# Altium schematic sheet Display.SchDoc
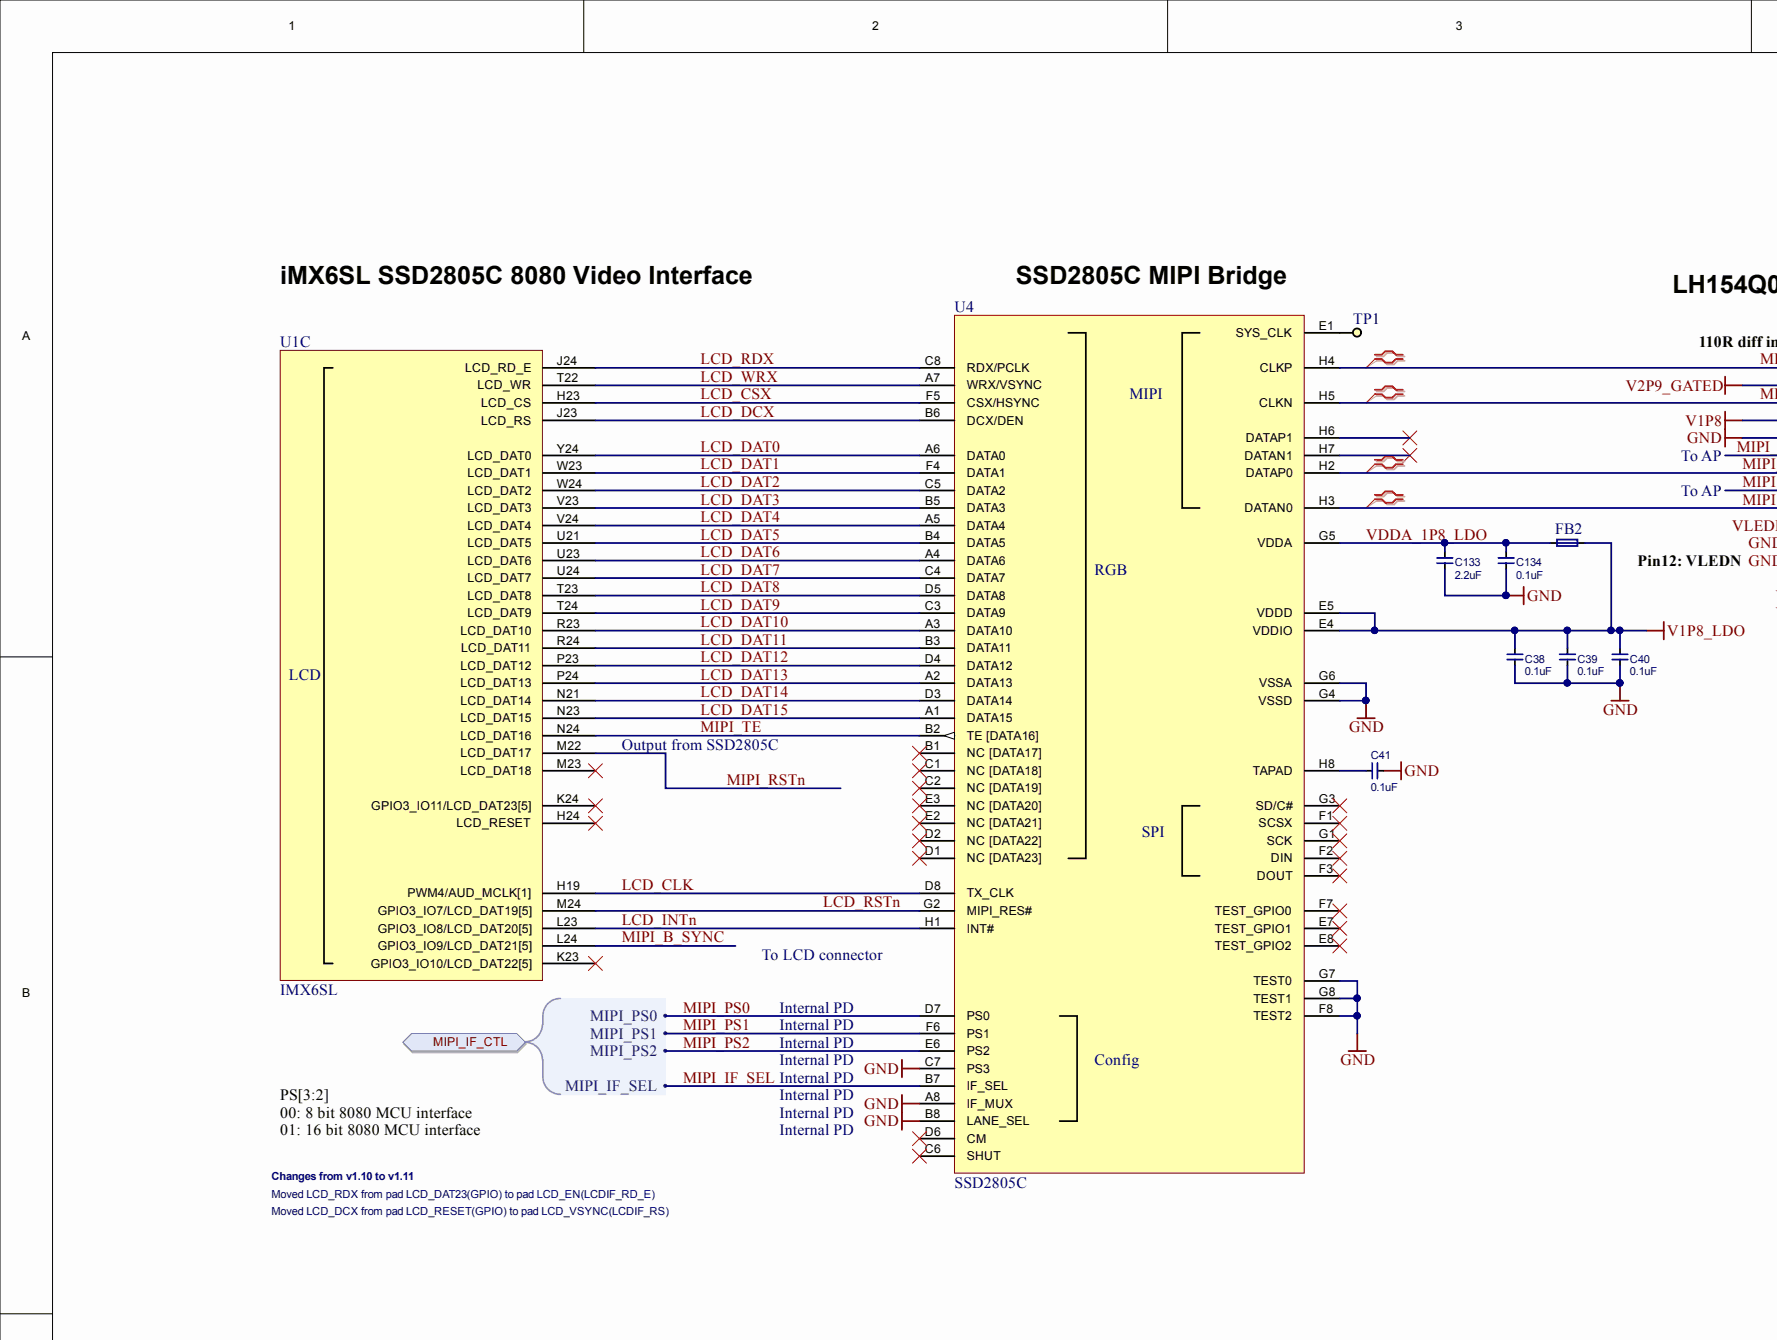
[diagram p0: Display.SchDoc, top left]
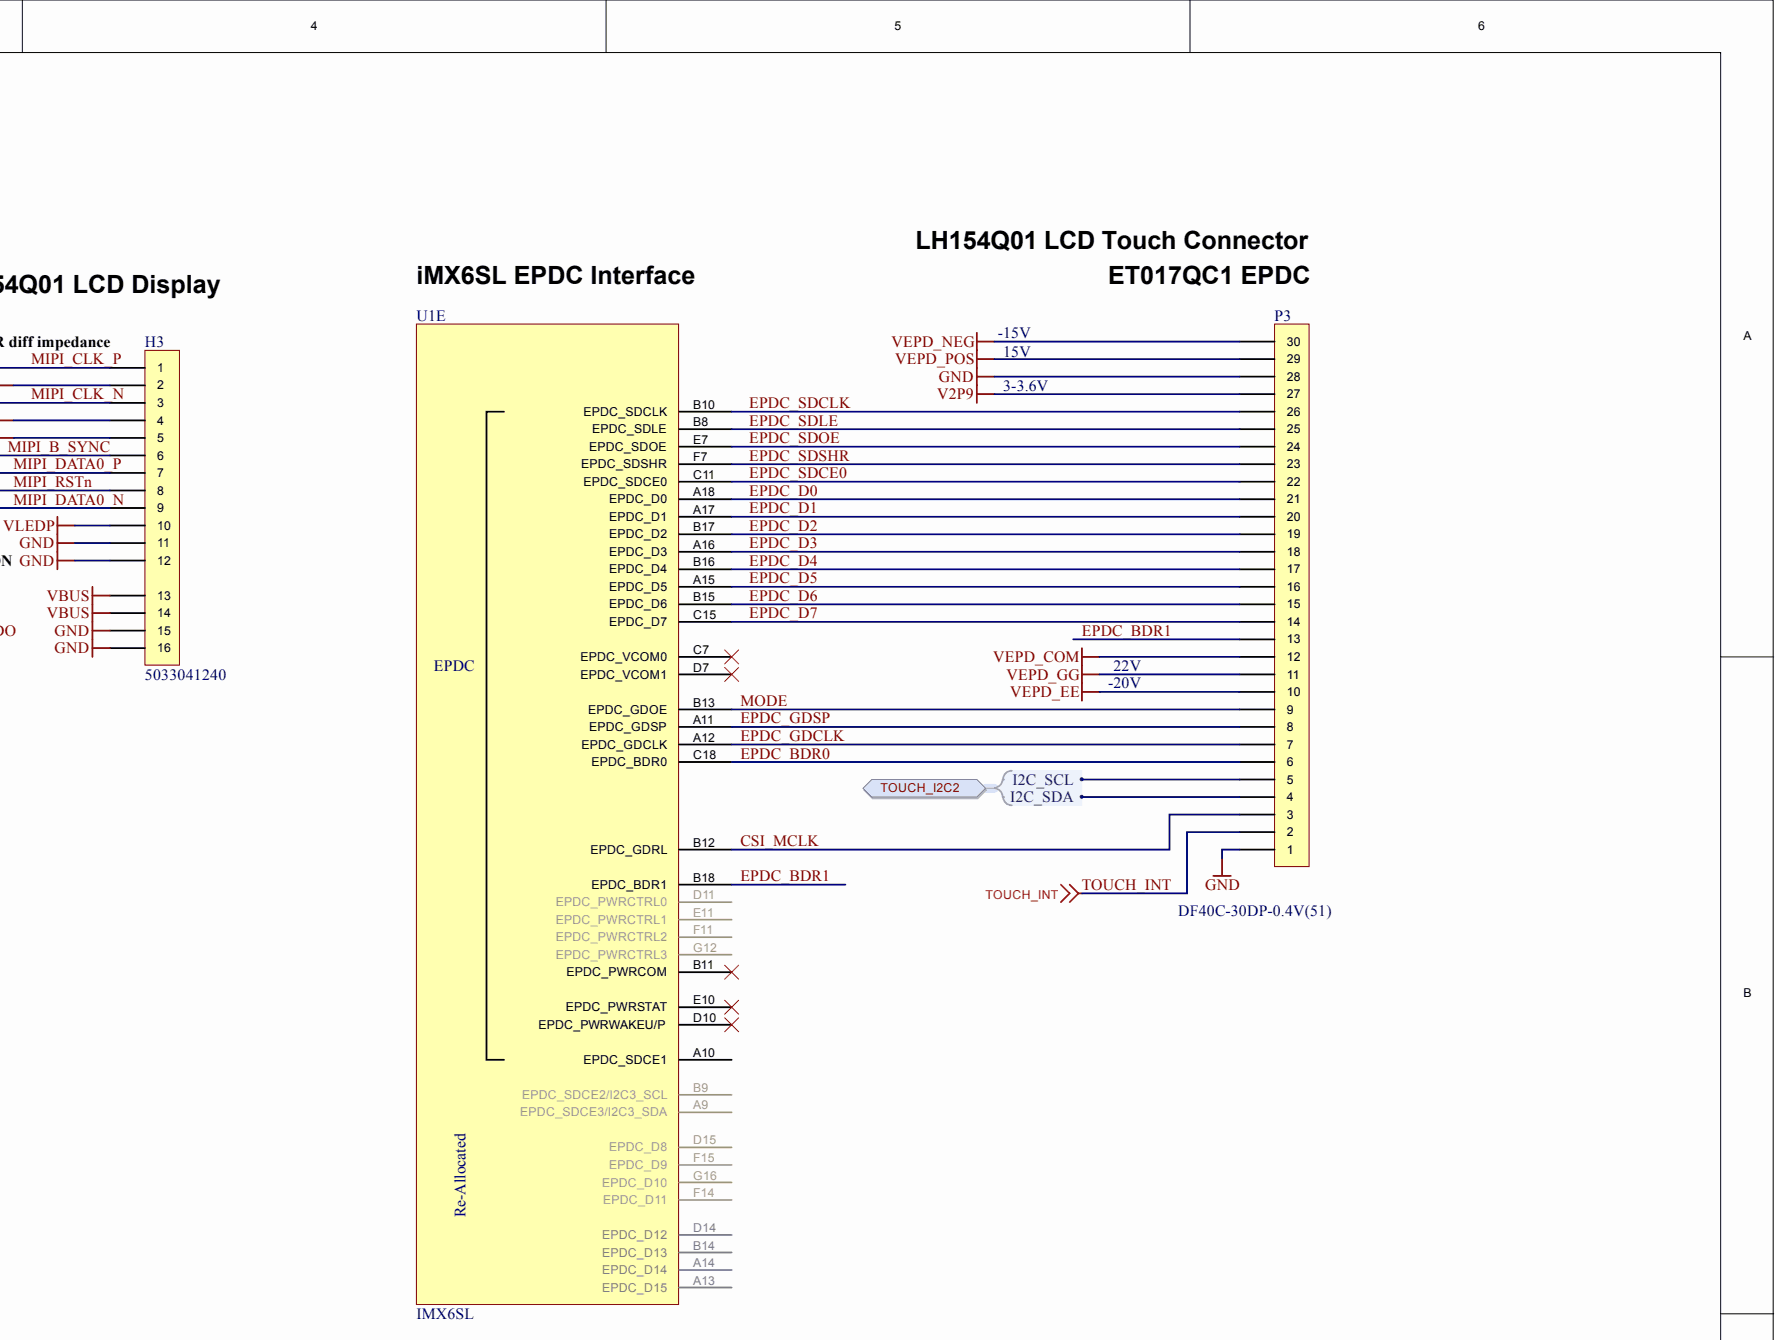
[diagram p1: Display.SchDoc, top right]
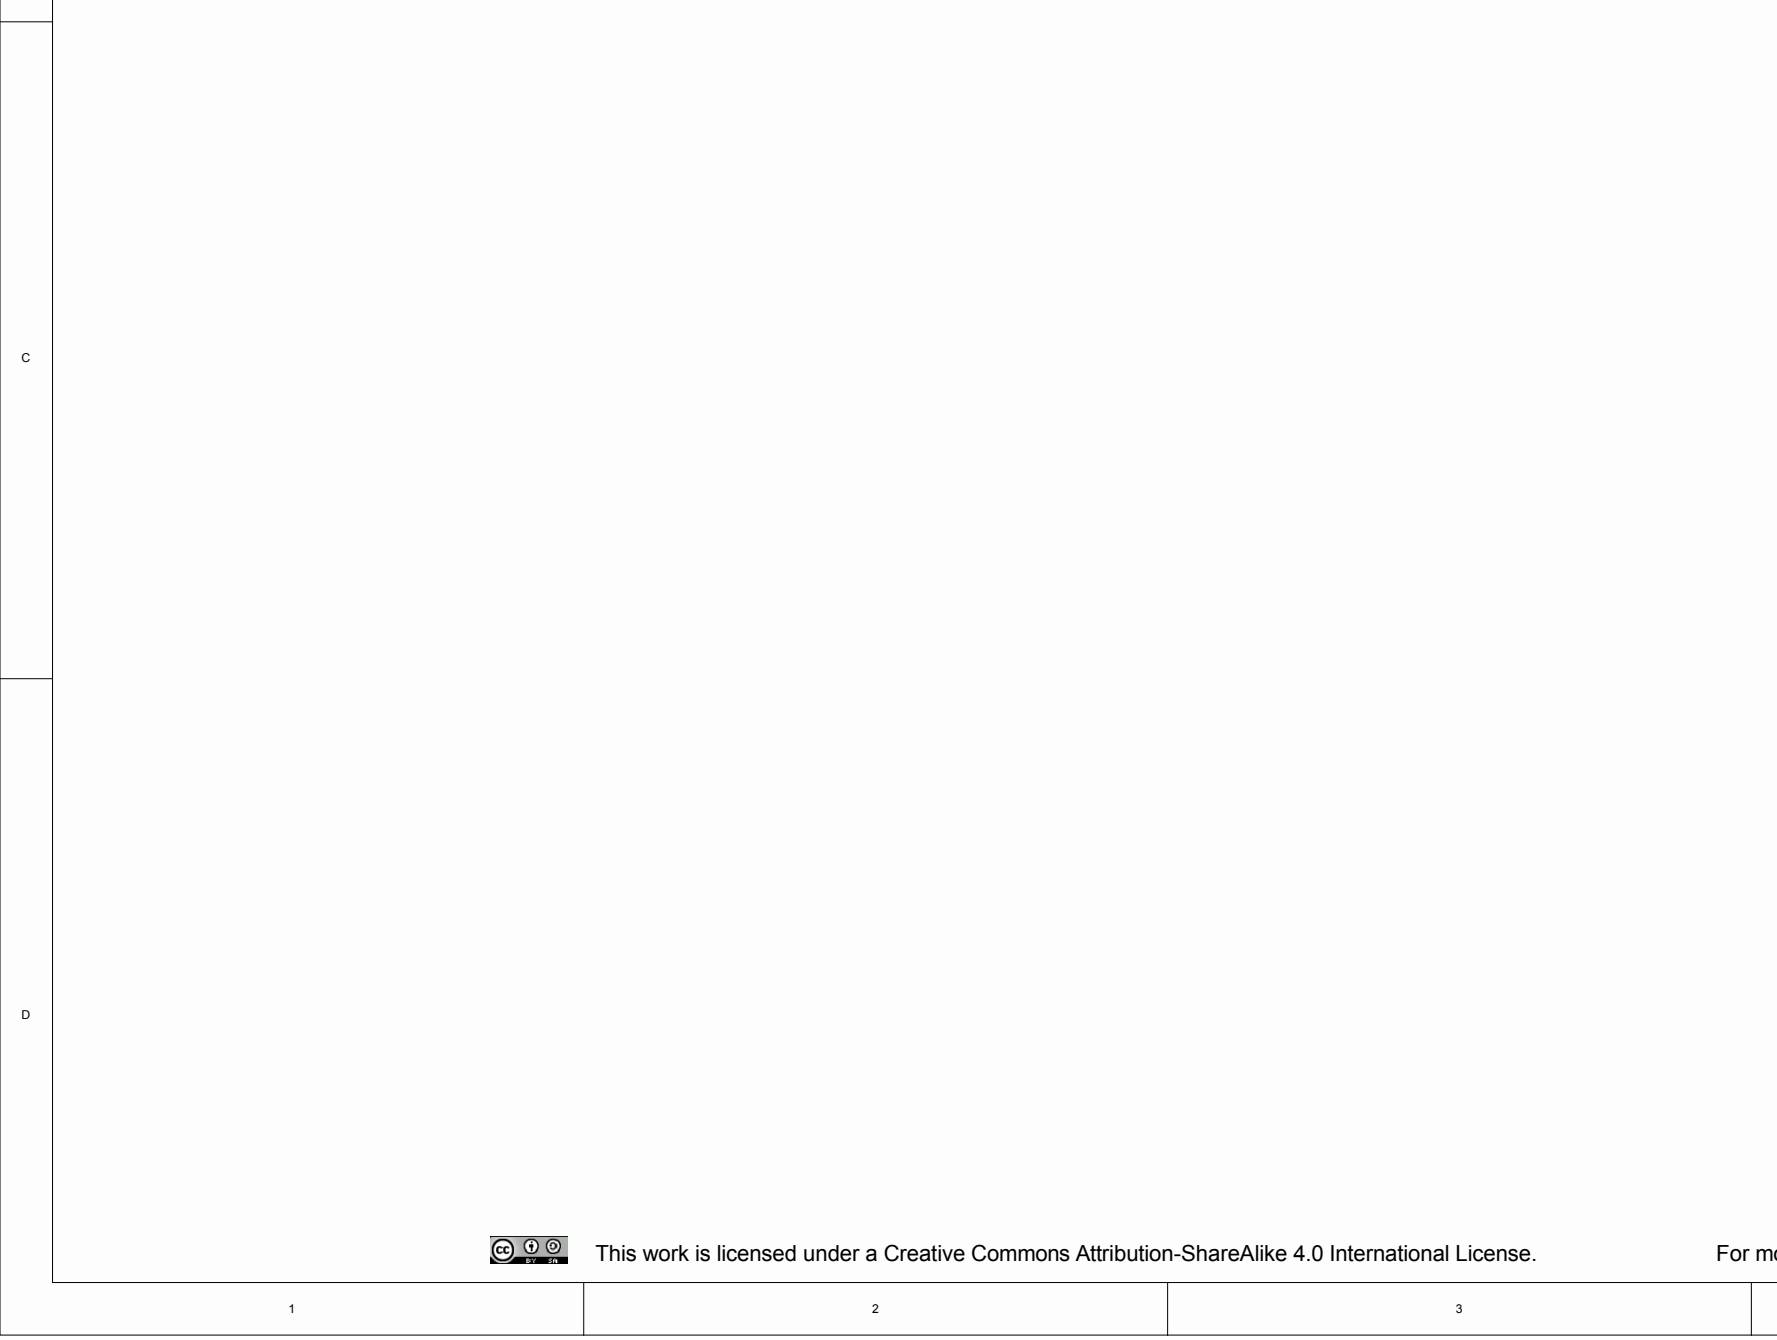
[diagram p2: Display.SchDoc, bottom left]
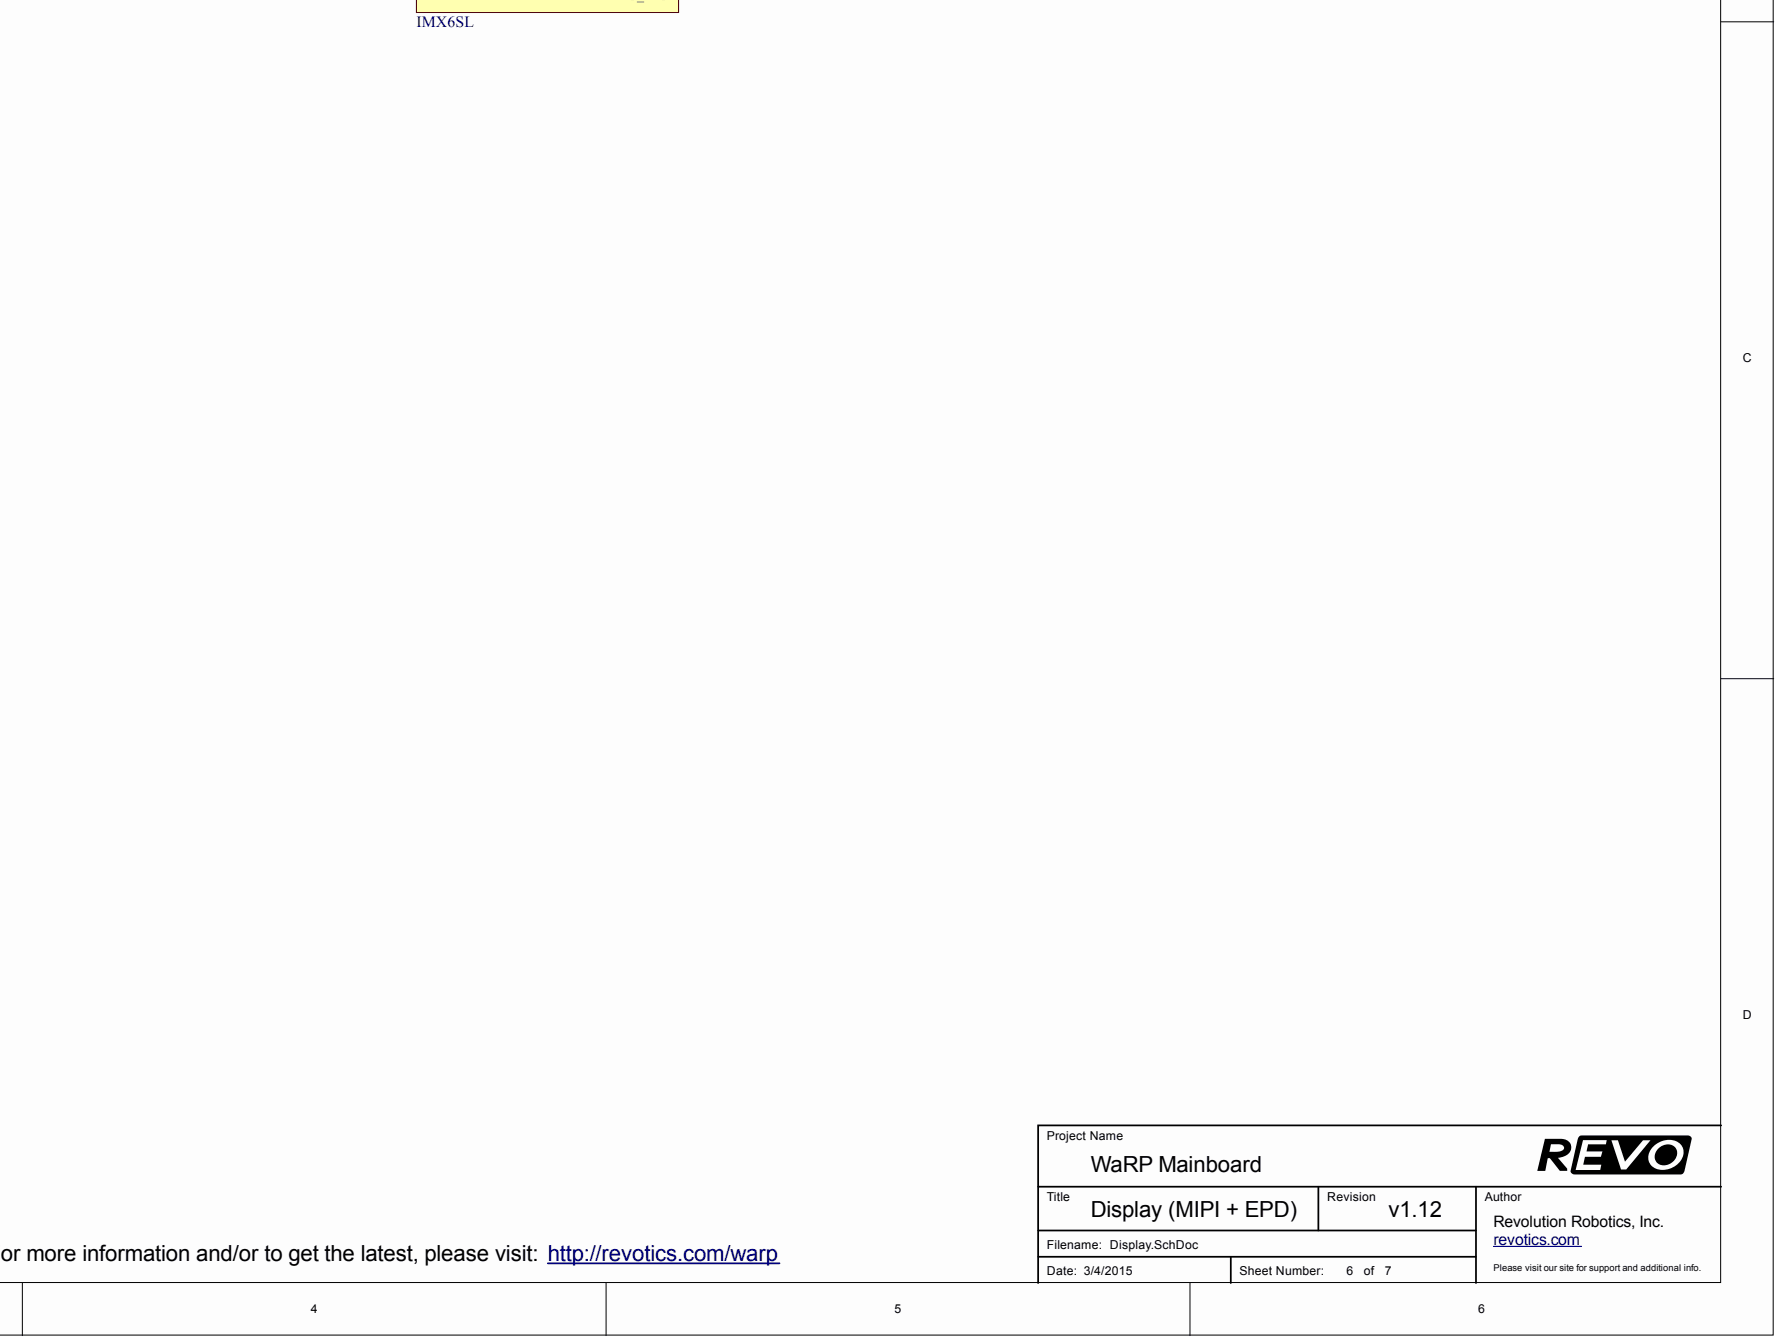
[diagram p3: Display.SchDoc, bottom right]

## Parts
C38  value 0.1uF  [0201 (0603) Cap High Density]  (hidden comment: CapacitorSM)
C39  value 0.1uF  [0201 (0603) Cap High Density]  (hidden comment: CapacitorSM)
C40  value 0.1uF  [0201 (0603) Cap High Density]  (hidden comment: CapacitorSM)
C41  value 0.1uF  [0201 (0603) Cap High Density]  (hidden comment: CapacitorSM)
C133  value 2.2uF  [0402 (1005) Cap High Density]  (hidden comment: CapacitorSM)
C134  value 0.1uF  [0201 (0603) Cap High Density]  (hidden comment: CapacitorSM)
FB2  Ferrite Bead  [0201 (0603) IND High DENSITY]  (value not drawn)
H3  503304-1240  Part No.: 5033041240  [SlimStack 0.4mm, 12Pin]
P3  DF40C-30DP-0.4V(51)  [DF40C-30DP-0.4V(51)]
TP1  TP  [8mil]  (no BOM)
U1  IMX6SL  [BGA432C50P24X24_1300X1300X110]  (parts C,E)
U4  SSD2805C  [SSD2805C]

## Ports
MIPI_IF_CTL, MIPI_IF_CTL.MIPI_IF_SEL, MIPI_IF_CTL.MIPI_PS0, MIPI_IF_CTL.MIPI_PS1, MIPI_IF_CTL.MIPI_PS2, TOUCH_I2C2, TOUCH_I2C2.I2C_SCL, TOUCH_I2C2.I2C_SDA

## Nets
GND: C38.2 C39.2 C40.2 C41.2 C133.2 C134.2 H3.5 H3.11 H3.12 H3.15 H3.16 P3.1 P3.28 U4.A8(IF_MUX) U4.B8(LANE_SEL) U4.C7(PS3) U4.F8(TEST2) U4.G4(VSSD) U4.G6(VSSA) U4.G7(TEST0) U4.G8(TEST1)
V1P8: H3.4
V1P8_LDO: C38.1 C39.1 C40.1 FB2.2 U4.E4(VDDIO) U4.E5(VDDD)
V2P9: P3.27
V2P9_GATED: H3.2
VBUS: H3.13 H3.14
VEPD_COM: P3.12
VEPD_EE: P3.10
VEPD_GG: P3.11
VEPD_NEG: P3.30
VEPD_POS: P3.29
VLEDP: H3.10
CSI_MCLK: P3.3 U1.B12(EPDC_GDRL)
EPDC_BDR0: P3.6 U1.C18(EPDC_BDR0)
EPDC_BDR1: P3.13 U1.B18(EPDC_BDR1)
EPDC_D0: P3.21 U1.A18(EPDC_D0)
EPDC_D1: P3.20 U1.A17(EPDC_D1)
EPDC_D2: P3.19 U1.B17(EPDC_D2)
EPDC_D3: P3.18 U1.A16(EPDC_D3)
EPDC_D4: P3.17 U1.B16(EPDC_D4)
EPDC_D5: P3.16 U1.A15(EPDC_D5)
EPDC_D6: P3.15 U1.B15(EPDC_D6)
EPDC_D7: P3.14 U1.C15(EPDC_D7)
EPDC_GDCLK: P3.7 U1.A12(EPDC_GDCLK)
EPDC_GDSP: P3.8 U1.A11(EPDC_GDSP)
EPDC_SDCE0: P3.22 U1.C11(EPDC_SDCE0)
EPDC_SDCLK: P3.26 U1.B10(EPDC_SDCLK)
EPDC_SDLE: P3.25 U1.B8(EPDC_SDLE)
EPDC_SDOE: P3.24 U1.E7(EPDC_SDOE)
EPDC_SDSHR: P3.23 U1.F7(EPDC_SDSHR)
LCD_CLK: U1.H19(PWM4/AUD_MCLK[1]) U4.D8(TX_CLK)
LCD_CSX: U1.H23(LCD_CS) U4.F5(CSX/HSYNC)
LCD_DAT0: U1.Y24(LCD_DAT0) U4.A6(DATA0)
LCD_DAT1: U1.W23(LCD_DAT1) U4.F4(DATA1)
LCD_DAT2: U1.W24(LCD_DAT2) U4.C5(DATA2)
LCD_DAT3: U1.V23(LCD_DAT3) U4.B5(DATA3)
LCD_DAT4: U1.V24(LCD_DAT4) U4.A5(DATA4)
LCD_DAT5: U1.U21(LCD_DAT5) U4.B4(DATA5)
LCD_DAT6: U1.U23(LCD_DAT6) U4.A4(DATA6)
LCD_DAT7: U1.U24(LCD_DAT7) U4.C4(DATA7)
LCD_DAT8: U1.T23(LCD_DAT8) U4.D5(DATA8)
LCD_DAT9: U1.T24(LCD_DAT9) U4.C3(DATA9)
LCD_DAT10: U1.R23(LCD_DAT10) U4.A3(DATA10)
LCD_DAT11: U1.R24(LCD_DAT11) U4.B3(DATA11)
LCD_DAT12: U1.P23(LCD_DAT12) U4.D4(DATA12)
LCD_DAT13: U1.P24(LCD_DAT13) U4.A2(DATA13)
LCD_DAT14: U1.N21(LCD_DAT14) U4.D3(DATA14)
LCD_DAT15: U1.N23(LCD_DAT15) U4.A1(DATA15)
LCD_DCX: U1.J23(LCD_RS) U4.B6(DCX/DEN)
LCD_INTN: U1.L23(GPIO3_IO8/LCD_DAT20[5]) U4.H1(INT#)
LCD_RDX: U1.J24(LCD_RD_E) U4.C8(RDX/PCLK)
LCD_RSTN: U1.M24(GPIO3_IO7/LCD_DAT19[5]) U4.G2(MIPI_RES#)
LCD_WRX: U1.T22(LCD_WR) U4.A7(WRX/VSYNC)
MIPI_B_SYNC: H3.6 U1.L24(GPIO3_IO9/LCD_DAT21[5])
MIPI_CLK_N: H3.3 U4.H5(CLKN)
MIPI_CLK_P: H3.1 U4.H4(CLKP)
MIPI_DATA0_N: H3.9 U4.H3(DATAN0)
MIPI_DATA0_P: H3.7 U4.H2(DATAP0)
MIPI_IF_SEL: U4.B7(IF_SEL) ; port MIPI_IF_CTL
MIPI_PS0: U4.D7(PS0) ; port MIPI_IF_CTL
MIPI_PS1: U4.F6(PS1) ; port MIPI_IF_CTL
MIPI_PS2: U4.E6(PS2) ; port MIPI_IF_CTL
MIPI_RSTN: H3.8 U1.M22(LCD_DAT17)
MIPI_TE: U1.N24(LCD_DAT16) U4.B2(TE_[DATA16])
MODE: P3.9 U1.B13(EPDC_GDOE)
TOUCH_INT: P3.2
VDDA_1P8_LDO: C133.1 C134.1 FB2.1 U4.G5(VDDA)
NetC41_1: C41.1 U4.H8(TAPAD)
NetP3_4: P3.4 ; port TOUCH_I2C2
NetP3_5: P3.5 ; port TOUCH_I2C2
NetTP1_1: TP1.1 U4.E1(SYS_CLK)
NetU1_D11: U1.D11(EPDC_PWRCTRL0)
NetU1_E11: U1.E11(EPDC_PWRCTRL1)
NetU1_F11: U1.F11(EPDC_PWRCTRL2)
NetU1_G12: U1.G12(EPDC_PWRCTRL3)

Not connected: U1 A9(EPDC_SDCE3/I2C3_SDA) A10(EPDC_SDCE1) A13(EPDC_D15) A14(EPDC_D14) B9(EPDC_SDCE2/I2C3_SCL) B11(EPDC_PWRCOM) B14(EPDC_D13) C7(EPDC_VCOM0) D7(EPDC_VCOM1) D10(EPDC_PWRWAKEU/P) D14(EPDC_D12) D15(EPDC_D8) E10(EPDC_PWRSTAT) F14(EPDC_D11) F15(EPDC_D9) G16(EPDC_D10) H24(LCD_RESET) K23(GPIO3_IO10/LCD_DAT22[5]) K24(GPIO3_IO11/LCD_DAT23[5]) M23(LCD_DAT18); U4 B1(NC_[DATA17]) C1(NC_[DATA18]) C2(NC_[DATA19]) C6(SHUT) D1(NC_[DATA23]) D2(NC_[DATA22]) D6(CM) E2(NC_[DATA21]) E3(NC_[DATA20]) E7(TEST_GPIO1) E8(TEST_GPIO2) F1(SCSX) F2(DIN) F3(DOUT) F7(TEST_GPIO0) G1(SCK) G3(SD/C#) H6(DATAP1) H7(DATAN1)

## Library data of the parts
C38-C41 C134 = CapacitorSM: Capacitor; Manufacturer: Murata; Part No.: GRM033R60J104ME19D; Vendor: Digikey; Voltage: 6.3; Component Kind: Standard; Library Name: Passive.SchLib
C133 = CapacitorSM: Capacitor; Manufacturer: Taiyo Yuden; Part No.: LMK105BJ225MV-F; Vendor: Digikey; Component Kind: Standard; Library Name: Passive.SchLib
FB2 = Ferrite Bead: Manufacturer: Murata; Part No.: BLM03AX100SN1D; Note: 10 Ohms Impedance 0.035ohm Resistance 1A Current Limit; Vendor: Mouser; Component Kind: Standard; Description: Ferrite Bead; Library Name: Passive.SchLib
H3 = 503304-1240: SlimStack 0.4mm, 12Pin - Receptacle; Manufacturer: Molex Inc; Component Kind: Standard; ComponentLink1Description: http://www.molex.com/pdm_docs/sd/5033041240_sd.pdf; ComponentLink1URL: http://www.molex.com/pdm_docs/sd/5033041240_sd.pdf; Library Name: Molex SlimStack.SchLib
P3 = DF40C-30DP-0.4V(51): 30pin, 0.4mm, Hirose B2B Connector; Vendor: Digikey; Component Kind: Standard; ComponentLink1Description: Datasheet; Library Name: Hirose Connectors.SchLib; Manufacturer: Hirose; Part No.: DF40C-30DP-0.4V(51); ComponentLink1URL: R:\Hardware\Part Datasheets\Connectors\ed_DF40_20120316.pdf
TP1 = TP: Test Pad; Library Name: TestPads.SchLib
U1 = IMX6SL: Freescale iMX6 SoloLite; Part No.: MCIMX6L8DVN10AB; Vendor: Verical; Component Kind: Standard; ComponentLink1Description: Datasheet; ComponentLink2Description: Freescale Webpage; ComponentLink2URL: http://www.freescale.com/webapp/sps/site/prod_summary.jsp?code=i.MX6SL; Library Name: iMX6.SchLib; Manufacturer: Freescale; Supplier 1: Newark; Supplier Part Number 1: 31W8709; ComponentLink1URL: R:\Hardware\Part Datasheets\Freescale\IMX6SLCEC.pdf
U4 = SSD2805C: SPI/RGB to MIPI master bridge; Manufacturer: Solomon Systech; Component Kind: Standard; ComponentLink1Description: Datasheet; Library Name: SSD2805C.SchLib; Part no.: SSD2805C; ComponentLink1URL: R:\Hardware\Part Datasheets\MIPI\SSD2805C.pdf
